# Altium schematic sheet USB Type-C Male Connector.SchDoc
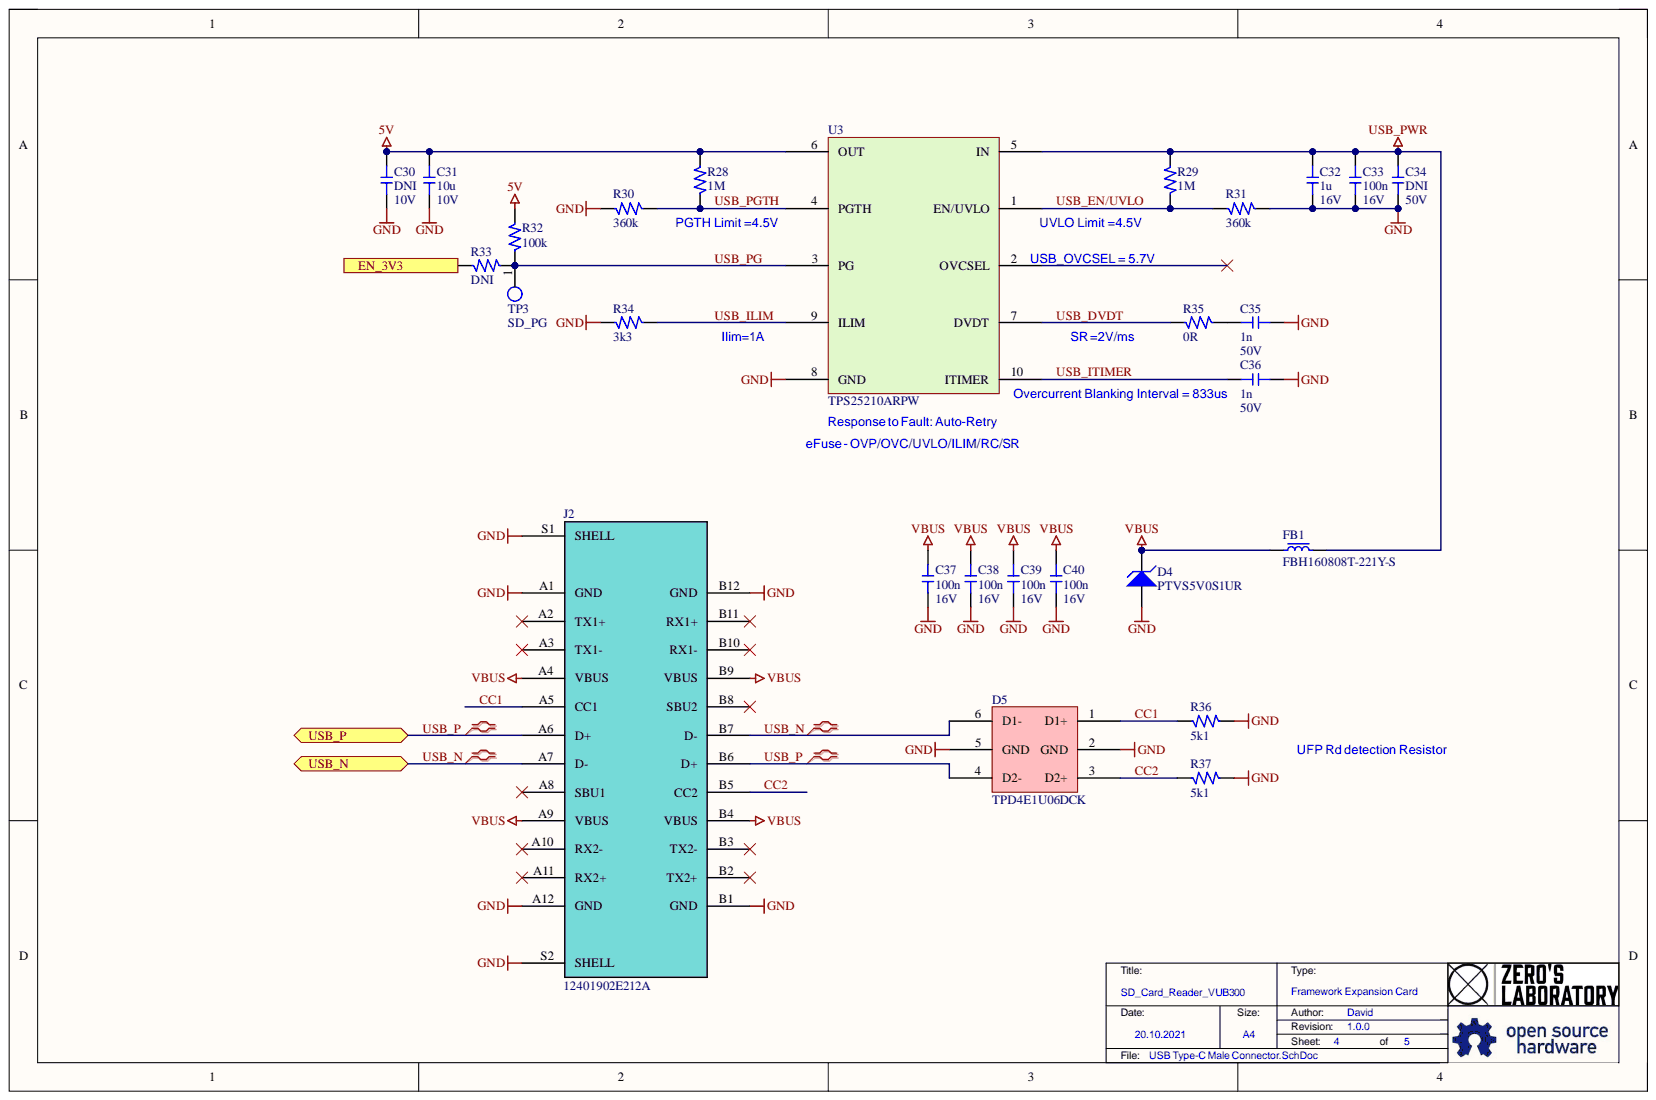
[diagram p0: USB Type-C Male Connector.SchDoc]

## Parts
C30  DNI  Voltage: 10V  [[1206]_CAPC320X160X160L50]
C31  10u  Voltage: 10V  [[1206]_CAPC320X160X160L50]
C32  1u  Voltage: 16V  [[0603]_CAPC160X80X100L40]
C33  100n  Voltage: 16V  [[0402]_CAPC100X50X50L25]
C34  DNI  Voltage: 50V  [[0402]_CAPC100X50X50L25]
C35  1n  [[0402]_CAPC100X50X50L25]
C36  1n  Voltage: 50V  [[0402]_CAPC100X50X50L25]
C37  100n  Voltage: 16V  [[0402]_CAPC100X50X50L25]
C38  100n  Voltage: 16V  [[0402]_CAPC100X50X50L25]
C39  100n  Voltage: 16V  [[0402]_CAPC100X50X50L25]
C40  100n  Voltage: 16V  [[0402]_CAPC100X50X50L25]
D4  PTVS5V0S1UR
D5  TPD4E1U06DCK  [SC706P65_200X210X95L35X25]
FB1  FBH160808T-221Y-S  [[0402]_FBC100X50X50L25]
J2  12401902E212A
R28  1M  [[0402]_RESC100X50X35L25]
R29  1M  [[0402]_RESC100X50X35L25]
R30  360k  [[0402]_RESC100X50X35L25]
R31  360k  [[0402]_RESC100X50X35L25]
R32  100k  [[0402]_RESC100X50X35L25]
R33  DNI  [[0402]_RESC100X50X35L25]
R34  3k3  [[0402]_RESC100X50X35L25]
R35  0R  [[0402]_RESC100X50X35L25]
R36  5k1  [[0402]_RESC100X50X35L25]
R37  5k1  [[0402]_RESC100X50X35L25]
TP3  SD_PG  [TP_0.8MM_SMD]  (no BOM)
U3  TPS25210ARPW

## Ports
EN_3V3, USB_N, USB_P

## Nets
5V: C30.1 C31.1 R28.1 R32.2 U3.6(OUT)
GND: C30.2 C31.2 C32.1 C33.1 C34.1 C35.2 C36.2 C37.1 C38.1 C39.1 C40.1 D4.A D5.2(GND) D5.5(GND) J2.A1(GND) J2.A12(GND) J2.B1(GND) J2.B12(GND) J2.S1(SHELL) J2.S2(SHELL) R30.2 R31.1 R34.2 R36.1 R37.1 U3.8(GND)
USB_PWR: C32.2 C33.2 C34.2 FB1.2 R29.2 U3.5(IN)
VBUS: C37.2 C38.2 C39.2 C40.2 D4.K FB1.1 J2.A4(VBUS) J2.A9(VBUS) J2.B4(VBUS) J2.B9(VBUS)
CC1: D5.1(D1+) J2.A5(CC1) R36.2
CC2: D5.3(D2+) J2.B5(CC2) R37.2
EN_3V3: R33.1 ; port EN_3V3
USB_DVDT: R35.2 U3.7(DVDT)
USB_EN/UVLO: R29.1 R31.2 U3.1(EN/UVLO)
USB_ILIM: R34.1 U3.9(ILIM)
USB_ITIMER: C36.1 U3.10(ITIMER)
USB_N: D5.6(D1-) J2.A7(D-) J2.B7(D-) ; port USB_N
USB_P: D5.4(D2-) J2.A6(D+) J2.B6(D+) ; port USB_P
USB_PG: R32.1 R33.2 TP3.1 U3.3(PG)
USB_PGTH: R28.2 R30.1 U3.4(PGTH)
NetC35_1: C35.1 R35.1

Not connected: J2 A2(TX1+) A3(TX1-) A8(SBU1) A10(RX2-) A11(RX2+) B2(TX2+) B3(TX2-) B8(SBU2) B10(RX1-) B11(RX1+); U3 2(OVCSEL)

## Library data of the parts
C30 = Capacitor: Manufacturer: CAL-CHIP ELECTRONICS; MPN: GMC31X7R106K10NT; Capacitance: 10uF; Dielectric: X7R; Tolerance: ±10%; Type: Ceramic; Value: 10u; Component Kind: Standard; Description: Capacitor; Library Name: Capacitor.SchLib; Operating Temperature [°C]: -55 to +125; Package: 1206[3216]; Package Type: SMD; Size: 2.00mm x 1.25mm
C31 = Capacitor: Manufacturer: CAL-CHIP ELECTRONICS; MPN: GMC31X7R106K10NT; Capacitance: 10uF; Dielectric: X7R; Tolerance: ±10%; Type: Ceramic; Component Kind: Standard; Description: Capacitor; Library Name: Capacitor.SchLib; Operating Temperature [°C]: -55 to +125; Package: 1206[3216]; Package Type: SMD; Size: 2.00mm x 1.25mm; Value: 10u
C32 = Capacitor: Manufacturer: CAL-CHIP ELECTRONICS; MPN: GMC10X7R105K16NT; Capacitance: 1uF; Dielectric: X7R; Tolerance: ±10%; Type: Ceramic; Component Kind: Standard; Description: Capacitor; Library Name: Capacitor.SchLib; Operating Temperature [°C]: -55 to +125; Package: 0603[1608]; Package Type: SMD; Size: 1.60mm x 0.80mm; Value: 1u
C33 C37-C40 = Capacitor: Manufacturer: CAL-CHIP ELECTRONICS; MPN: GMC04X7R104K16NT; Capacitance: 100nF; Dielectric: X7R; Tolerance: ±10%; Type: Ceramic; Component Kind: Standard; Description: Capacitor; Library Name: Capacitor.SchLib; Operating Temperature [°C]: -55 to +125; Package: 0402[1005]; Package Type: SMD; Size: 1.00mm x 0.50mm; Value: 100n
C34 = Capacitor: Manufacturer: CAL-CHIP ELECTRONICS; MPN: GMC04X7R102K50NT; Capacitance: 1nF; Dielectric: X7R; Tolerance: ±10%; Type: Ceramic; Value: 1n; Component Kind: Standard; Description: Capacitor; Library Name: Capacitor.SchLib; Operating Temperature [°C]: -55 to +125; Package: 0402[1005]; Package Type: SMD; Size: 1.00mm x 0.50mm
C35 = Capacitor: Manufacturer: CAL-CHIP ELECTRONICS; MPN: GMC04X7R102K50NT; Capacitance: 1nF; Dielectric: X7R; Tolerance: ±10%; Type: Ceramic; Voltage: 50V; Component Kind: Standard; Description: Capacitor; Library Name: Capacitor.SchLib; Operating Temperature [°C]: -55 to +125; Package: 0402[1005]; Package Type: SMD; Size: 1.00mm x 0.50mm; Value: 1n
C36 = Capacitor: Manufacturer: CAL-CHIP ELECTRONICS; MPN: GMC04X7R102K50NT; Capacitance: 1nF; Dielectric: X7R; Tolerance: ±10%; Type: Ceramic; Component Kind: Standard; Description: Capacitor; Library Name: Capacitor.SchLib; Operating Temperature [°C]: -55 to +125; Package: 0402[1005]; Package Type: SMD; Size: 1.00mm x 0.50mm; Value: 1n
D4 = TVS Diode: Manufacturer: Nexperia; MPN: PTVS5V0S1UR,115; Ifsm: 50A; Ippm: 43.5A; Name: PTVSxS1UR; Rated Power: 400W; Vbr: 6.7V; Vc: 9.2V; Vrwm: 5V; Component Kind: Standard; Description: TVS Diode; Library Name: Nexperia.SchLib; Operating Temperature [°C]: -55 to +150; Package: SOD123W; Package Type: SMD; Size: 1.70mm x 3.50mm; Value: PTVS5V0S1UR; Web: https://www.nexperia.com/products/esd-protection-tvs-filtering-and-signal-conditioning/automotive-esd-protection-and-tvs/automotive-transient-voltage-suppressors-tvs/PTVS5V0S1UR.html
D5 = TPD4E1U06DCKR: Quad-Channel, High-Speed ESD Protection Device; Manufacturer: Texas Instruments; Cl: 0.8pF; Type: ESD Diode; Component Kind: Standard; Library Name: TexasInstruments.SchLib; MPN: TPD4E1U06DCK; MSL: Level-1-260C-UNLIM; Name: TPD4E1U06; Operating temperature [°C]: -40 to +125; Package: SC70; Package Type: SMD; Size: 2.00mm x 1.25mm; Value: TPD4E1U06DCK; Web: https://www.ti.com/product/TPD4E1U06
FB1 = Ferrite Bead: DCR Max: 80mΩ; Impedance@100MHz: 220Ω; Rated Current: 2A; Component Kind: Standard; Description: Ferrite Bead; Library Name: FerriteBead.SchLib; MPN: FBH160808T-221Y-S; Name: FBH160808T; Operating Temperature [°C]: -55 to +125; Package: 0603[1608]; Package Type: SMD; Size: 1.60mm x 0.80mm; Value: FBH160808T-221Y-S; Web: http://www.calchipelectronics.com/pdf/fbh_series.pdf
J2 = CUSM3112095001: USB, Type-C Male, Mid-mount SMT; Manufacturer: Csconn; Current Rating: 3A; Data Rate: 5Gbps; Pitch: 0.5mm; Voltage: 5V; Component Kind: Standard; Library Name: Csconn.SchLib; MPN: CUSM3112095001; Name: CUSM3112095001; Package: Type-C Male; Package Type: SMD; Type: Type-C Male Connector; Value: 12401902E212A; Web: https://www.csconn.com/UserFiles/upload/file/CUSM3112095001.pdf
R28 R29 = Resistor: Rated Power: 62.5mW; Resistance: 1MΩ; Tolerance: ±1%; Voltage: 50V; Component Kind: Standard; Description: Resistor; Library Name: Resistor.SchLib; Operating Temperature [°C]: -55 to +155; Package: 0402[1005]; Package Type: SMD; Size: 1.00mm x 0.50mm; Value: 1M
R30 R31 = Resistor: Rated Power: 62.5mW; Resistance: 360kΩ; Tolerance: ±1%; Voltage: 50V; Component Kind: Standard; Description: Resistor; Library Name: Resistor.SchLib; Operating Temperature [°C]: -55 to +155; Package: 0402[1005]; Package Type: SMD; Size: 1.00mm x 0.50mm; Value: 360k
R32 = Resistor: Rated Power: 62.5mW; Resistance: 100kΩ; Tolerance: ±1%; Voltage: 50V; Component Kind: Standard; Description: Resistor; Library Name: Resistor.SchLib; Operating Temperature [°C]: -55 to +155; Package: 0402[1005]; Package Type: SMD; Size: 1.00mm x 0.50mm; Value: 100k
R33 = Resistor: Rated Power: 62.5mW; Resistance: 0Ω; Tolerance: ±1%; Value: 0R; Voltage: 50V; Component Kind: Standard; Description: Resistor; Library Name: Resistor.SchLib; Operating Temperature [°C]: -55 to +155; Package: 0402[1005]; Package Type: SMD; Size: 1.00mm x 0.50mm
R34 = Resistor: Rated Power: 62.5mW; Resistance: 3.3kΩ; Tolerance: ±1%; Voltage: 50V; Component Kind: Standard; Description: Resistor; Library Name: Resistor.SchLib; Operating Temperature [°C]: -55 to +155; Package: 0402[1005]; Package Type: SMD; Size: 1.00mm x 0.50mm; Value: 3k3
R35 = Resistor: Rated Power: 62.5mW; Resistance: 0Ω; Tolerance: ±1%; Voltage: 50V; Component Kind: Standard; Description: Resistor; Library Name: Resistor.SchLib; Operating Temperature [°C]: -55 to +155; Package: 0402[1005]; Package Type: SMD; Size: 1.00mm x 0.50mm; Value: 0R
R36 R37 = Resistor: Rated Power: 62.5mW; Resistance: 5.1kΩ; Tolerance: ±1%; Voltage: 50V; Component Kind: Standard; Description: Resistor; Library Name: Resistor.SchLib; Operating Temperature [°C]: -55 to +155; Package: 0402[1005]; Package Type: SMD; Size: 1.00mm x 0.50mm; Value: 5k1
TP3 = TestPoint: Test Pad; Library Name: Miscellaneous.SchLib; Value: SD_PG
U3 = TPS25210ARPW: 2.7 - 5.7 V, 4 A, 31-mΩ True Reverse Current Blocking eFuse with Input Reverse Polarity Protection; Manufacturer: Texas Instruments; Pitch: 0.45mm; Component Kind: Standard; Library Name: TexasInstruments.SchLib; MPN: TPS25210ARPW; MSL: Level-2-260C-1 YEAR; Name: TPS2521; Operating temperature [°C]: -40 to +125; Package: QFN-10; Package Type: SMD; Size: 2.00mm x 2.00mm; Type: eFuse; Value: TPS25210ARPW; Web: https://www.ti.com/product/TPS2521
